# Altium schematic sheet [2]power.SchDoc
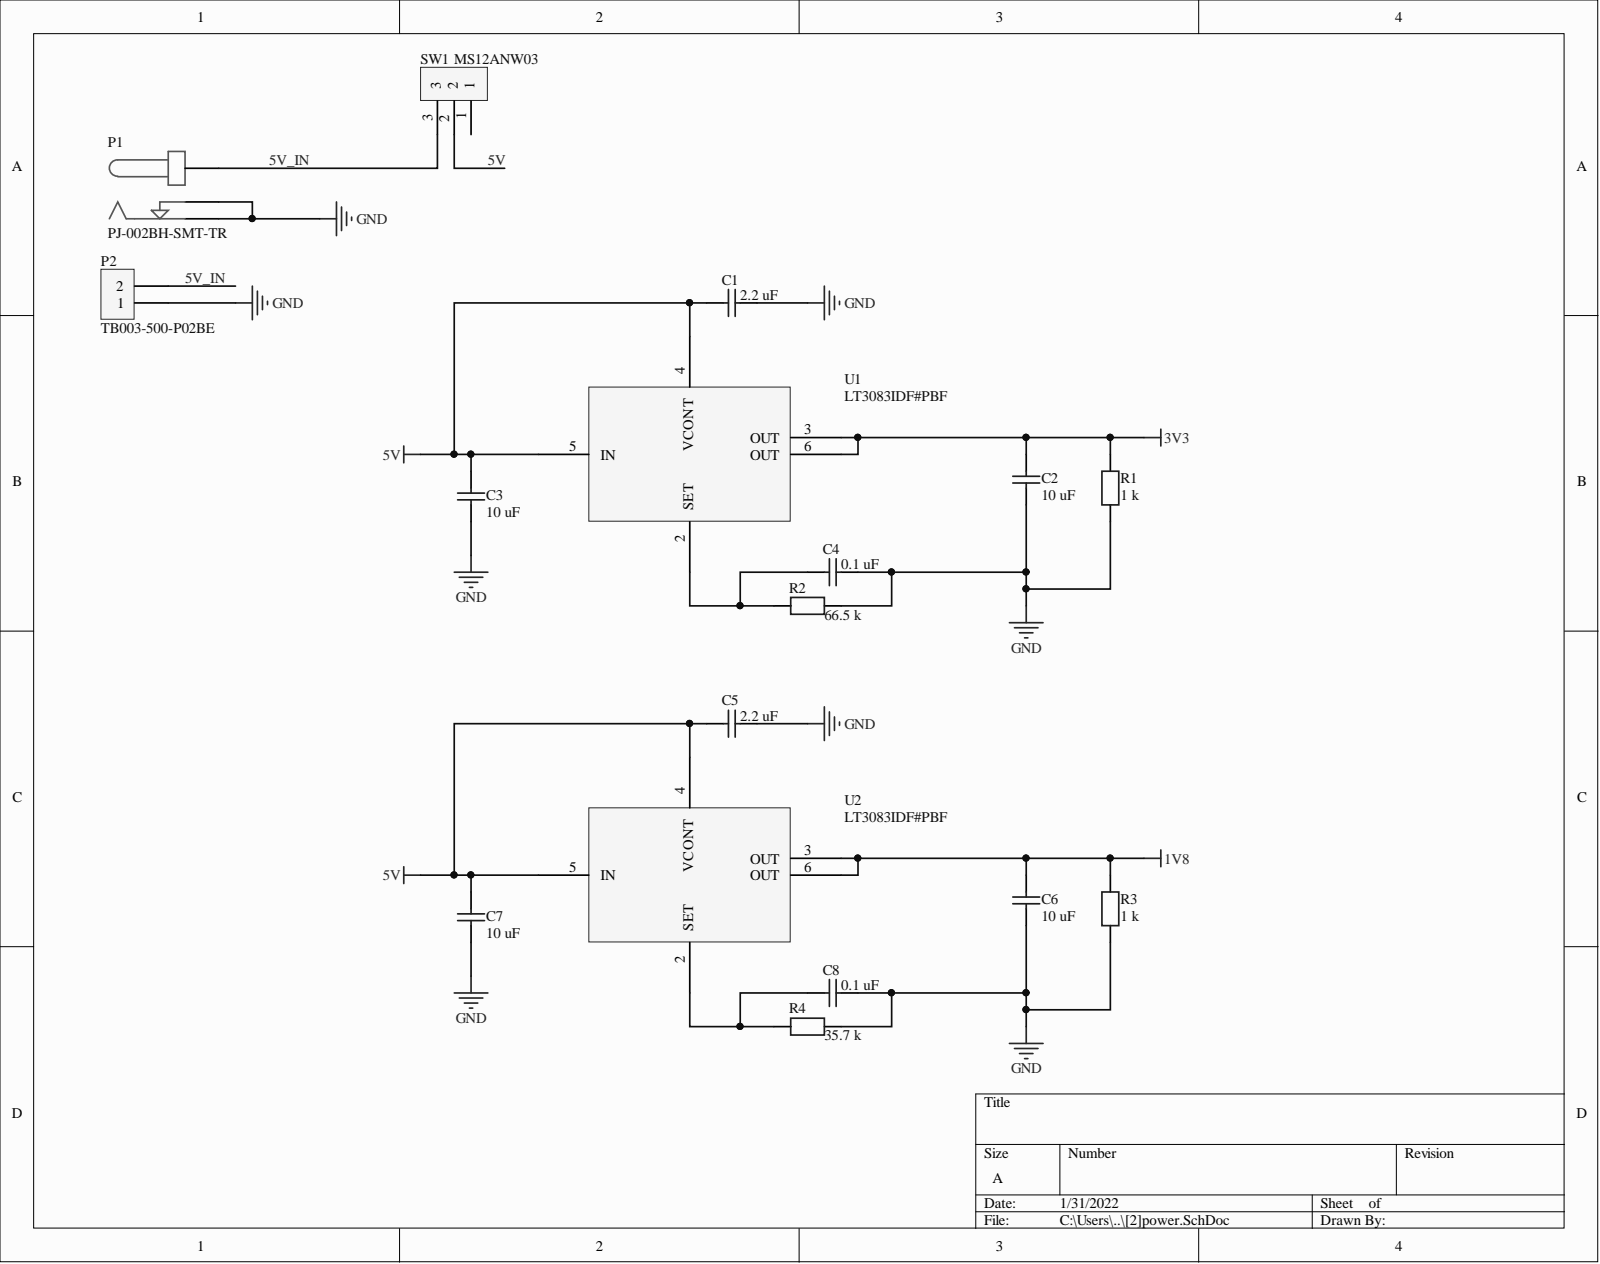
[diagram p0: [2]power.SchDoc]

## Parts
C1  value 2.2 uF  [0402-CAP-ASM]  (hidden comment: Cap)
C2  value 10 uF  [0805-CAP-ASM]  (hidden comment: Cap)
C3  value 10 uF  [0805-CAP-ASM]  (hidden comment: Cap)
C4  value 0.1 uF  [0402-CAP-ASM]  (hidden comment: Cap)
C5  value 2.2 uF  [0402-CAP-ASM]  (hidden comment: Cap)
C6  value 10 uF  [0805-CAP-ASM]  (hidden comment: Cap)
C7  value 10 uF  [0805-CAP-ASM]  (hidden comment: Cap)
C8  value 0.1 uF  [0402-CAP-ASM]  (hidden comment: Cap)
P1  PJ-002BH-SMT-TR  [PJ-002BH-SMT-TR]
P2  TB003-500-P02BE  [TB003-500-P02BE]
R1  value 1 k  [0402-RES-ASM]  (hidden comment: res)
R2  value 66.5 k  [0402-RES-ASM]  (hidden comment: res)
R3  value 1 k  [0402-RES-ASM]  (hidden comment: res)
R4  value 35.7 k  [0402-RES-ASM]  (hidden comment: res)
SW1  MS12ANW03  [MS12ANW03]
U1  LT3083IDF#PBF  [DFN12-LT3083IDF#PBF]
U2  LT3083IDF#PBF  [DFN12-LT3083IDF#PBF]

## Nets
1V8: C6.2 R3.2 U2.3(OUT) U2.6(OUT)
3V3: C2.2 R1.2 U1.3(OUT) U1.6(OUT)
GND: C1.2 C2.1 C3.1 C4.2 C5.2 C6.1 C7.1 C8.2 P1.2 P1.3 P2.1 R1.1 R2.2 R3.1 R4.2
5V: C1.1 C3.2 C5.1 C7.2 SW1.2 U1.4(VCONT) U1.5(IN) U2.4(VCONT) U2.5(IN)
5V_IN: P1.1 P2.2 SW1.3
NetC4_1: C4.1 R2.1 U1.2(SET)
NetC8_1: C8.1 R4.1 U2.2(SET)

Not connected: SW1 1

## Library data of the parts
C1 C5 = Cap: CAP CER 2.2UF 16V X5R 0402; Component Kind: Standard; Library Name: Miscellaneous Devices.IntLib; Supplier Part Number: 490-10456-1-ND
C2 C3 C6 C7 = Cap: CAP CER 10UF 25V JB 0805; Component Kind: Standard; Library Name: Miscellaneous Devices.IntLib; Supplier Part Number: 445-11463-1-ND
C4 C8 = Cap: CAP CER 0.1UF 10V X5R 0402; Component Kind: Standard; Library Name: Miscellaneous Devices.IntLib; Supplier Part Number: 587-1227-1-ND
P1 = PJ-002BH-SMT-TR: CONN PWR JACK 2.5X5.5MM SOLDER; Manufacturer: CUI Inc.; Component Kind: Standard; Library Name: Mylib..SchLib; Manufacturer Part Number: PJ-002BH-SMT-TR; Mounting Type: Surface Mount, Right Angle; Supplier: Digi-Key; Supplier Part Number: CP-002BHPJCT-ND
P2 = TB003-500-P02BE: TERMINAL BLOCK, SCREW TYPE, 5.00; Component Kind: Standard; Library Name: Mylib..SchLib; Supplier Part Number: 102-6161-ND
R1 R3 = RESISTOR: RES SMD 1K OHM 1% 1/16W 0402; Component Kind: Standard; Library Name: Mylib..SchLib; Supplier Part Number: YAG1234CT-ND
R2 = RESISTOR: RES SMD 66.5KOHM 0.1% 1/16W 0402; Component Kind: Standard; Library Name: Mylib..SchLib; Supplier Part Number: YAG4298CT-ND
R4 = RESISTOR: RES SMD 35.7KOHM 0.1% 1/16W 0402; Component Kind: Standard; Library Name: Mylib..SchLib; Supplier Part Number: A102810CT-ND
SW1 = MS12ANW03: SWITCH SLIDE SPDT 6A 125V; Component Kind: Standard; Library Name: Mylib..SchLib; Supplier Part Number: 360-2385-ND
U1 U2 = LT3083IDF#PBF: IC REG LINEAR POS ADJ 3A 12DFN; Component Kind: Standard; Library Name: Mylib..SchLib; Supplier Part Number: LT3083IDF#PBF-ND
